# Altium schematic sheet Power.SchDoc
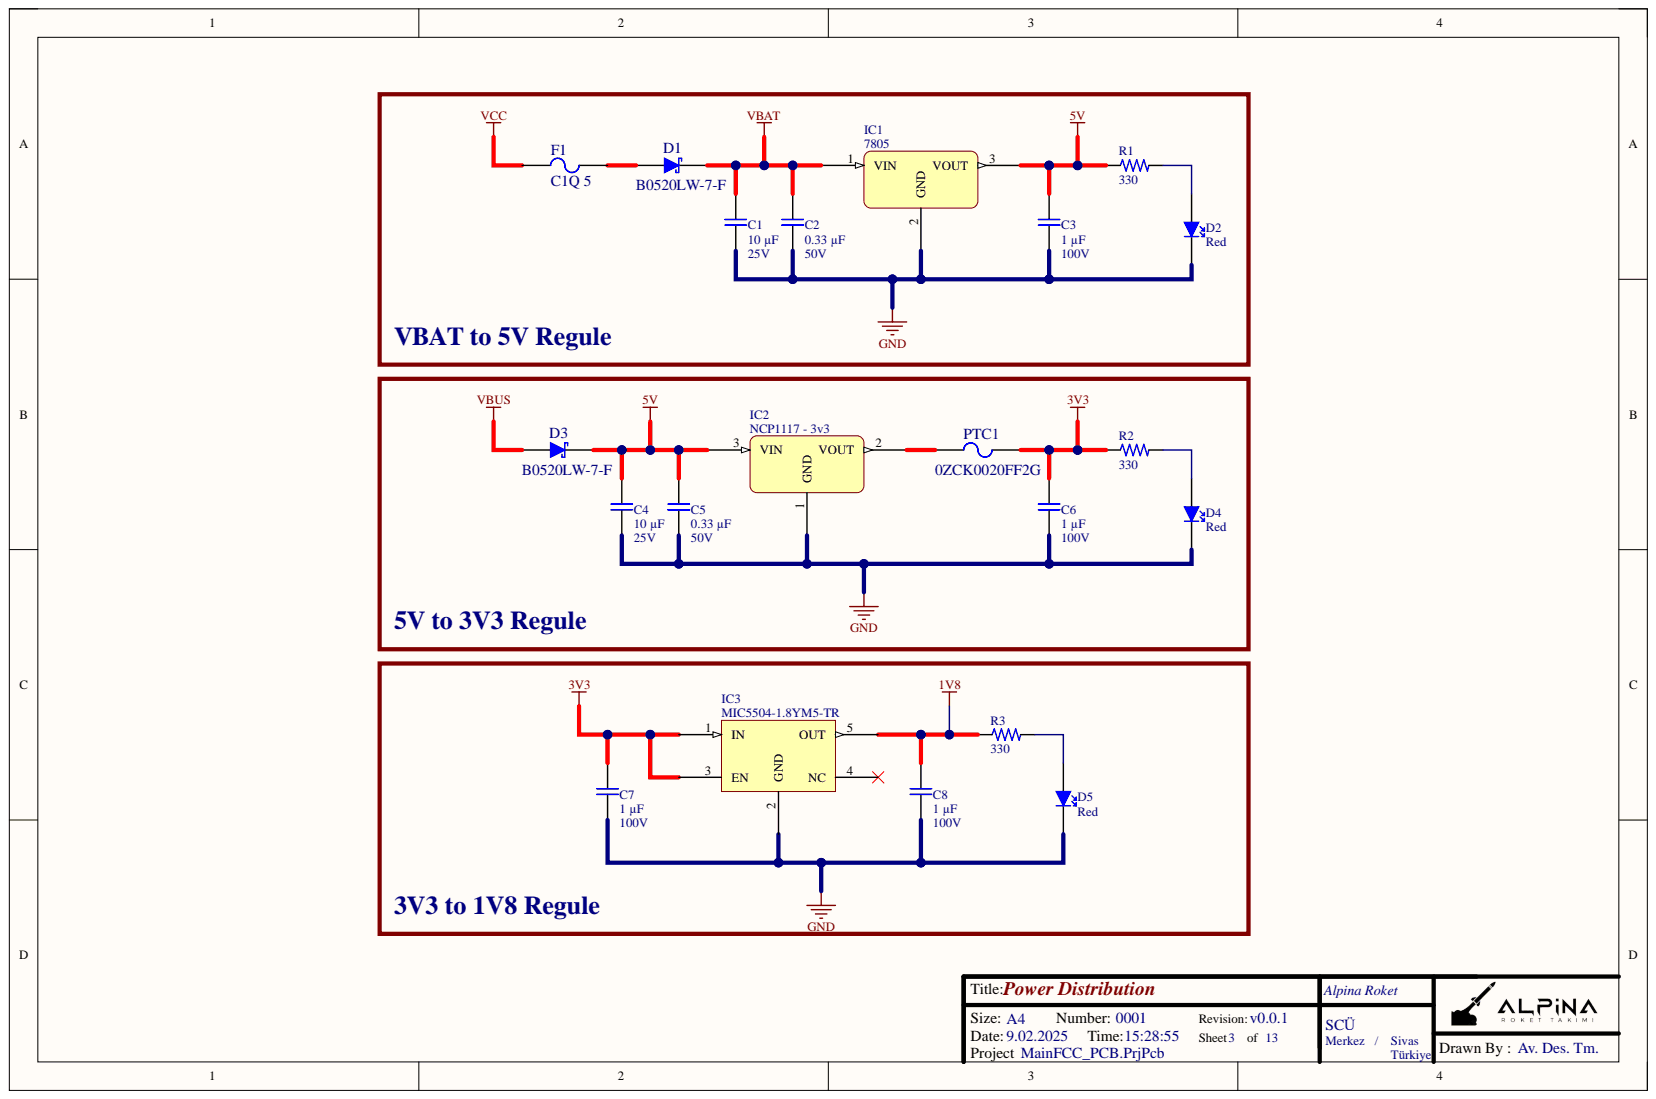
[diagram p0: Power.SchDoc]

## Parts
C1  10 µF  Voltage Rating: 25V  [MergenElektronik_Capacitors_Ceramics_SMDs_0603_L_NS]
C2  0.33 µF  Voltage Rating: 50V  [MergenElektronik_Capacitors_Ceramics_SMDs_0603_L_NS]
C3  1 µF  Voltage Rating: 100V  [MergenElektronik_Capacitors_Ceramics_SMDs_1206_S_NS]
C4  10 µF  Voltage Rating: 25V  [MergenElektronik_Capacitors_Ceramics_SMDs_0603_M_NS]
C5  0.33 µF  Voltage Rating: 50V  [MergenElektronik_Capacitors_Ceramics_SMDs_0603_M_NS]
C6  1 µF  Voltage Rating: 100V  [MergenElektronik_Capacitors_Ceramics_SMDs_1206_M_NS]
C7  1 µF  Voltage Rating: 100V  [MergenElektronik_Capacitors_Ceramics_SMDs_1206_M_NS]
C8  1 µF  Voltage Rating: 100V  [MergenElektronik_Capacitors_Ceramics_SMDs_1206_M_NS]
D1  B0520LW-7-F  [MergenElektronik_Diodes_SchottkyDiodes_SOD-523]
D2  Red  [MergenElektronik_Leds_SMDs_0603_M_NS]
D3  B0520LW-7-F  [MergenElektronik_Diodes_SchottkyDiodes_SOD-523]
D4  Red  [MergenElektronik_Leds_SMDs_0603_M_NS]
D5  Red  [MergenElektronik_Leds_SMDs_0603_M_NS]
F1  C1Q 5  [MergenElektronik_CircuitProtections_Fuses_1206_l]
IC1  7805  [MergenElektronik_Regulators_Linears_DPAK]
IC2  NCP1117 - 3v3  [MergenElektronik_Regulators_Linears_SOT223]
IC3  MIC5504-1.8YM5-TR  [MergenElektronik_Regulators_Linears_SOT-23-5]
PTC1  0ZCK0020FF2G  [MergenElektronik_CircuitProtections_PTCs_0805_M_NS]
R1  330  [MergenElektronik_Resistors_ChipResistors_0603_M_NS]
R2  330  [MergenElektronik_Resistors_ChipResistors_0603_M_NS]
R3  330  [MergenElektronik_Resistors_ChipResistors_0603_M_NS]

## Nets
1V8: C8.1 IC3.5(OUT) R3.1
3V3: C6.1 C7.1 IC3.1(IN) IC3.3(EN) PTC1.2 R2.1
5V: C3.1 C4.1 C5.1 D3.2 IC1.3 IC2.3 R1.1
GND: C1.2 C2.2 C3.2 C4.2 C5.2 C6.2 C7.2 C8.2 D2.2 D4.2 D5.2 IC1.2 IC2.1 IC3.2(GND)
VBAT: C1.1 C2.1 D1.2 IC1.1
VBUS: D3.1
VCC: F1.1
NetD1_1: D1.1 F1.2
NetD2_1: D2.1 R1.2
NetD4_1: D4.1 R2.2
NetD5_1: D5.1 R3.2
NetPTC1_1: IC2.2 PTC1.1

Not connected: IC3 4(NC)

## Library data of the parts
C1 C4 = MergenElektronik_Capacitors_Ceramic_SMDs_10µF_25V: Mergen Elektronik - Capacitors - Ceramics - SMDs - 0603 - 10 µF - 25V; Manufacturer: Murata Electronics; Manufacturer Number: GRT188R61E106ME13D; Model: Ceramic Capacitors; Tolerance: ±20%; Component Kind: Standard; Digi-Key Number: 490-12323-1-ND; Farnell Number: 3581819; Library Name: MergenElektronik_Capacitors_Ceramics_SMDs.SchLib; Mounting Type: Surface Mount; Mouser Number: 81-GRT188R61E106ME3D; Package: 0603(1608); Supplier 1: Digi-Key; Supplier 2: Mouser; Supplier Part Number 1: 490-12323-1-ND; Supplier Part Number 2: 81-GRT188R61E106ME3D; Temperature: -55°C up to +85°C; Value: 10 µF
C2 C5 = MergenElektronik_Capacitors_Ceramic_SMDs_0.33µF_50V: Mergen Elektronik - Capacitors - Ceramics - SMDs - 0603 - 0.33 µF - 50V; Manufacturer: TDK Corporation; Manufacturer Number: C1608X7R1H334K080AC; Model: Ceramic Capacitors; Tolerance: ±10%; Component Kind: Standard; Digi-Key Number: 445-5950-1-ND; Farnell Number: 2346907; Library Name: MergenElektronik_Capacitors_Ceramics_SMDs.SchLib; Mounting Type: Surface Mount; Mouser Number: 810-C1608X7R1H334K; Package: 0603(1608); Supplier 1: Digi-Key; Supplier 2: Mouser; Supplier Part Number 1: 445-5950-1-ND; Supplier Part Number 2: 810-C1608X7R1H334K; Temperature: -55°C up to +125°C; Value: 0.33 µF
C3 C6-C8 = MergenElektronik_Capacitors_Ceramic_SMDs_1µF_100V: Mergen Elektronik - Capacitors - Ceramics - SMDs - 1206 - 1 µF - 100V; Manufacturer: Samsung Electro-Mechanics; Manufacturer Number: CL31B105KCHNNNE; Model: Ceramic Capacitors; Tolerance: ±10%; Component Kind: Standard; Digi-Key Number: 1276-1838-1-ND; Library Name: MergenElektronik_Capacitors_Ceramics_SMDs.SchLib; Mounting Type: Surface Mount; Mouser Number: 187-CL31B105KCHNNNE; Package: 1206(3216 Metric); Supplier 1: Digikey; Supplier 2: Mouser; Supplier Part Number 1: 1276-1838-1-ND; Supplier Part Number 2: 187-CL31B105KCHNNNE; Temperature: -55°C up to +125°C; Value: 1 µF; Özdisan Number: CL31B105KCHNNNE
D1 D3 = MergenElektronik_Diodes_SchottkyDiodes_B0520LW-7-F: Mergen Elektronik - Diodes - Schottky Diodes - B0520LW-7-F; Manufacturer: Diodes Incorporated; Breakdown Voltage: 20V; Current Rating: 500mA; Forward Voltage: 385 mV @ 500mA; Component Kind: Standard; Digi-Key Number: B0520LW-FDITR-ND; Farnell Number: 1773476; Library Name: MergenElektronik_Diodes_SchottkyDiodes.IntLib; Manufacturer Number: B0520LW-7-F; Model: Schottky Diodes; Mounting Type: Surface Mount; Mouser Number: 621-B0520LW-F; Package: SOD-123; Supplier 1: Digikey; Supplier 2: Mouser; Supplier Part Number 1: B0520LW-FDITR-ND; Supplier Part Number 2: 621-B0520LW-F; Temperature: -65°C up to +125°C; Value: B0520LW-7-F
D2 D4 D5 = MergenElektronik_LEDs_SMDs_Red_0603: Mergen Elektronik - Leds - SMDs - Red - 0603; Manufacturer: Würth Elektronik; Manufacturer Number: 150060RS75000; Model: SMD Leds; Voltage Rating: 2V; Color: Red; Component Kind: Standard; Digi-Key Number: 732-4978-1-ND; Farnell Number: 2322071; Library Name: MergenElektronik_Leds_SMDs.SchLib; Mounting Type: Surface Mount; Mouser Number: 710-150060RS75000; Package: 0603(1608); Supplier 1: Digi-Key; Supplier 2: Mouser; Supplier Part Number 1: 732-4978-1-ND; Supplier Part Number 2: 710-150060RS75000; Temperature: -45°C up to +85°C; Value: Red; Özdisan Number: 150060RS75000
F1 = MergenElektronik_CircuitProtections_Fuses_0C1Q 5: Mergen Elektronik - Circuit Protections - Fuses - 0C1Q 5; Manufacturer: Bel Fuse Inc.; Breaking Capacity: 100A @ AC,- 50A @ DC; Current Rating: 5 A; Melting I²t: 0.075; Model: Fuse; Response Time: Fast Blow; Voltage Rating: 125V @ AC - 63V @ DC; Component Kind: Standard; Digi-Key Number: 5923-C1Q5TR-ND; Library Name: MergenElektronik_CircuitProtections_Fuses.IntLib; Manufacturer Number: C1Q 5; Mounting Type: Surface Mount; Mouser Number: 530-0ZCK0020FF2G; Package: 1206(3216); Supplier 1: Digi-Key; Supplier Part Number 1: 507-1811-6-ND; Temperature: -40°C up to 85°C; Value: C1Q 5
IC1 = MergenElektronik_Regulators_Linears_MC7805BDTG: Mergen Elektronik - Regulators - Linears - MC7805BDTG; Manufacturer: onsemi; Current Rating: 1A; Model: Linear; Output Voltage: 5V; Voltage Rating: 7VDC up to 36V; Component Kind: Standard; Digi-Key Number: MC7805BDTGOS-ND; Farnell Number: 1652336; Library Name: MergenElektronik_Regulators_Linears.SchLib; Manufacturer Number: MC7805BDTG; Mounting Type: Surface Mount; Mouser Number: 863-MC7805BDTG; Package: DPAK; Supplier 1: Digi-Key; Supplier 2: Mouser; Supplier Part Number 1: MC7805BDTGOS-ND; Supplier Part Number 2: 863-MC7805BDTG; Temperature: -40°C up to +125°C; Value: MC7805BDTG; Özdisan Number: MC7805BDTG
IC2 = MergenElektronik_Regulators_Linears_NCP1117ST33T3G: Mergen Elektronik - Regulators - Linears - NCP1117ST33T3G; Manufacturer: onsemi; Current Rating: 1A; Model: Linear; Output Voltage: 3.3V; Voltage Rating: 4.75VDC up to 10V; Component Kind: Standard; Digi-Key Number: NCP1117ST33T3GOSCT-ND; Library Name: MergenElektronik_Regulators_Linears.SchLib; Manufacturer Number: NCP1117ST33T3G; Mounting Type: Surface Mount; Mouser Number: 863-NCP1117ST33T3G; Package: SOT-223; Supplier 1: Digi-Key; Supplier 2: Mouser; Supplier Part Number 1: NCP1117ST33T3GOSCT-ND; Supplier Part Number 2: 863-NCP1117ST33T3G; Temperature: 0°C up to +125°C; Value: NCP1117ST33T3G; Özdisan Number: NCP1117ST33T3G
IC3 = MergenElektronik_Regulators_Linears_MIC5504-1.8YM5-TR: Mergen Elektronik - Regulators - Linears - MIC5504-1.8YM5-TR; Manufacturer: Microchip Technology; Current Rating: 300mA; Model: Linear; Output Voltage: 1.8V(Fixed); Voltage Rating: 2.2V up to 5.5V; Component Kind: Standard; Digi-Key Number: 576-4879-2-ND; Farnell Number: 2809977; Library Name: MergenElektronik_Regulators_Linears.IntLib; Manufacturer Number: MIC5504-1.8YM5-TR; Mounting Type: Surface Mount; Mouser Number: 998-MIC5504-1.8YM5TR; Package: SOT-23-5; Supplier 1: Digikey; Supplier 2: Mouser; Supplier Part Number 1: 576-4879-2-ND; Supplier Part Number 2: 998-MIC5504-1.8YM5TR; Temperature: -40°C up to +125°C; Value: MIC5504-1.8YM5-TR
PTC1 = MergenElektronik_CircuitProtections_PTCs_0ZCK0020FF2G: Mergen Elektronik - Circuit Protections - PTCs - 0ZCK0020FF2G; Manufacturer: Bel Fuse Inc.; Current - Hold: 200 mA; Current - Max: 100A; Current - Trip: 500 mA; Model: PTC Fuse; Voltage Rating: 9V; Component Kind: Standard; Current Rating: 500 mA; Digi-Key Number: 507-1811-1-ND; Library Name: MergenElektronik_CircuitProtections_PTCs.SchLib; Manufacturer Number: 0ZCK0020FF2G; Mounting Type: Surface Mount; Mouser Number: 530-0ZCK0020FF2G; Package: 0805(2012); Supplier 1: Digi-Key; Supplier 2: Mouser; Supplier Part Number 1: 507-1811-6-ND; Supplier Part Number 2: 530-0ZCK0020FF2G; Temperature: -40°C up to 85°C; Value: 0ZCK0020FF2G
R1-R3 = MergenElektronik_Resistors_ChipResistors_0603_330R: Mergen Elektronik - Resistors - Chip Resistors - 0603 - 330R; Manufacturer: Stackpole Electronics Inc; Manufacturer Number: RMCF0603JT330R; Power: 1/10W; Tolerance: ±5%; Value: Chip Resistor - 0603 - 330R; Component Kind: Standard; Digi-Key Number: RMCF0603JT330RCT-ND; Library Name: MergenElektronik_Resistors_ChipResistors.IntLib; Model: Chip Resistor; Mounting Type: Surface Mount; Package: 0603(1608); Supplier 1: Digi-Key; Supplier Part Number 1: RMCF0603JT330RCT-ND; Temperature: -55°C up to +155°C - X8R
